# Altium schematic sheet Connections.SchDoc(Connections)
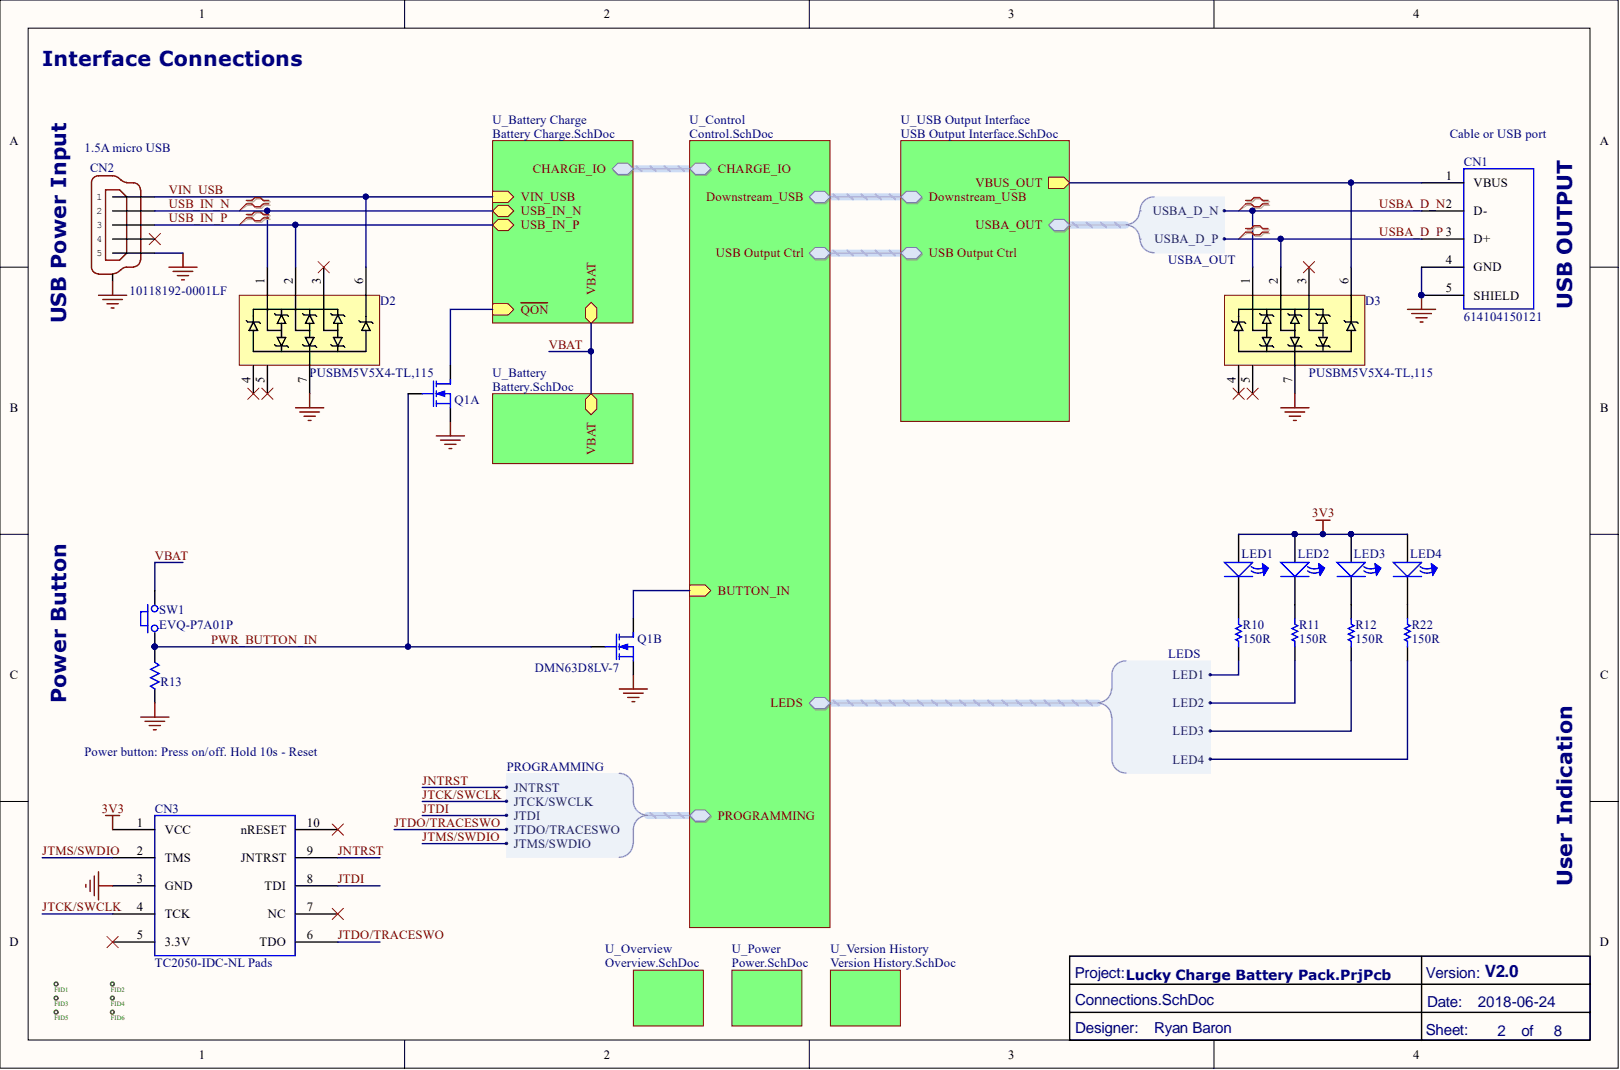
[diagram p0: Connections.SchDoc]

## Parts
CN1  614104150121  [614104150121]
CN2  10118192-0001LF  [10118192-0001LF]
CN3  TC2050-IDC-NL Pads  [TC2050-IDC-NL]  (no BOM)
D2  PUSBM5V5X4-TL,115  [SON50P160X160X50-7N120X50]
D3  PUSBM5V5X4-TL,115  [SON50P160X160X50-7N120X50]
FID1  Round Fiducial  [FIDU-ROUND]  (value not drawn)  (no BOM)
FID2  Round Fiducial  [FIDU-ROUND]  (value not drawn)  (no BOM)
FID3  Round Fiducial  [FIDU-ROUND]  (value not drawn)  (no BOM)
FID4  Round Fiducial  [FIDU-ROUND]  (value not drawn)  (no BOM)
FID5  Round Fiducial  [FIDU-ROUND]  (value not drawn)  (no BOM)
FID6  Round Fiducial  [FIDU-ROUND]  (value not drawn)  (no BOM)
LED1  VLMB1500-GS08  [LED0402]  (value not drawn)
LED2  VLMB1500-GS08  [LED0402]  (value not drawn)
LED3  VLMB1500-GS08  [LED0402]  (value not drawn)
LED4  VLMB1500-GS08  [LED0402]  (value not drawn)
Q1  DMN63D8LV-7  [SOT-563ALT]  (parts A,B)
R10  Resistance: 150R  [RES0402R]  (hidden comment: CRCW0402150RFKED)
R11  Resistance: 150R  [RES0402R]  (hidden comment: CRCW0402150RFKED)
R12  Resistance: 150R  [RES0402R]  (hidden comment: CRCW0402150RFKED)
R13  10K  [RES0402R]  (value not drawn)
R22  Resistance: 150R  [RES0402R]  (hidden comment: CRCW0402150RFKED)
SW1  EVQ-P7A01P  [EVQ-P7A01P]

## Nets
3V3: CN3.1(VCC) LED1.A LED2.A LED3.A LED4.A
GND: CN1.4(GND) CN1.5(SHIELD) CN2.0 CN2.5 CN3.3(GND) D2.7 D3.7 Q1.1 Q1.4 R13.1
JNTRST: CN3.9(JNTRST)
JTCK/SWCLK: CN3.4(TCK)
JTDI: CN3.8(TDI)
JTDO/TRACESWO: CN3.6(TDO)
JTMS/SWDIO: CN3.2(TMS)
PWR_BUTTON_IN: Q1.2 Q1.5 R13.2 SW1.1
USB_IN_N: CN2.2 D2.1
USB_IN_P: CN2.3 D2.2
USBA_D_N: CN1.2(D-) D3.1
USBA_D_P: CN1.3(D+) D3.2
VBAT: SW1.2
VIN_USB: CN2.1 D2.6
NetCN1_1: CN1.1(VBUS) D3.6
NetCN2_4: CN2.4
NetCN3_5: CN3.5(3.3V)
NetCN3_7: CN3.7(NC)
NetCN3_10: CN3.10(nRESET)
NetD2_3: D2.3
NetD2_4: D2.4
NetD2_5: D2.5
NetD3_3: D3.3
NetD3_4: D3.4
NetD3_5: D3.5
NetLED1_K: LED1.K R10.1
NetLED2_K: LED2.K R11.1
NetLED3_K: LED3.K R12.1
NetLED4_K: LED4.K R22.1
NetQ1_3: Q1.3
NetQ1_6: Q1.6
NetR10_2: R10.2
NetR11_2: R11.2
NetR12_2: R12.2
NetR22_2: R22.2
N00034:
N00035:
N00036:
N00037:
N00038:
N00039:
N00040:
N00041:
N00042:
N00043:
N00044:
N00045:
N00046:
N00047:

## Library data of the parts
CN1 = 614104150121: CONN RCPT USB TYPE A REV; Manufacturer: Wurth Electronics Inc.; Connector Type: USB - A; Current Rating: 1.5A; Gender: Receptacle; Material Flammability Rating: UL94 V-0; Mating Cycles: 1500; Number of Contacts: 4; Shielding: Shielded; Specifications: USB 2.0; Voltage - Rated: 30VAC; Category: Connectors, Interconnects; Component Kind: Standard; ComponentLink1Description: http://katalog.we-online.de/em/datasheet/614104150121.pdf; ComponentLink1URL: http://katalog.we-online.de/em/datasheet/614104150121.pdf; Contact Finish: Gold; Features: Board Lock; Ingress Protection: -; Insulation Color: Black; Library Name: PF Connectors.SchLib; Manufacturer Part Number: 614104150121; Mounting Feature: Horizontal; Mounting Type: Through Hole, Right Angle; Operating Temperature: -40°C ~ 85°C; Packaging: Cut Tape (CT); Part Status: Active; Pricing 1: 1=2.91, 10=2.673, 50=2.4746, 100=2.2763 (CAD); RoHS: RoHS Compliant; Shell/Shielding Material: Brass; Stock 1: 389; Supplier 1: Digi-Key; Termination: Solder
CN2 = 10118192-0001LF: CONN USB MICRO B RECPT SMT R/A; Manufacturer: Amphenol FCI; Connector Type: USB - micro B; Current Rating: 1.8A per Contact; Gender: Receptacle; Material Flammability Rating: UL94 V-0; Mating Cycles: 10000; Number of Contacts: 5; Shielding: Shielded; Specifications: USB 2.0; Voltage - Rated: 100VAC; Category: Connectors, Interconnects; Component Kind: Standard; ComponentLink1Description: https://cdn.amphenol-icc.com/media/wysiwyg/files/drawing/10118192.pdf; ComponentLink1URL: https://cdn.amphenol-icc.com/media/wysiwyg/files/drawing/10118192.pdf; Contact Finish: Gold; Features: Solder Retention; Ingress Protection: -; Insulation Color: Black; Library Name: Connectors.SchLib; Manufacturer Part Number: 10118192-0001LF; Mounting Feature: Flange, Horizontal; Mounting Type: Surface Mount, Right Angle; Operating Temperature: -30°C ~ 80°C; Packaging: Cut Tape (CT); Part Status: Active; Pricing 1: 1=0.58, 10=0.547, 25=0.4924, 50=0.4378, 100=0.4195, 250=0.38304, 500=0.3648 (CAD); RoHS: RoHS Compliant; Shell/Shielding Material: Steel, Stainless; Stock 1: 203700; Supplier 1: Digi-Key; Supplier Part Number 1: 609-4613-1-ND; Termination: Solder
CN3 = TC2050-IDC-NL-JTAG: CBL PLUG-OF-NAILS 10-PIN Pads; Manufacturer: Tag-Connect LLC; ComponentLink1Description: http://www.tag-connect.com/Materials/TC2050-IDC-NL%20Datasheet.pdf; ComponentLink1URL: http://www.tag-connect.com/Materials/TC2050-IDC-NL%20Datasheet.pdf; Library Name: PF Connectors.SchLib; Manufacturer Part Number: TC2050-IDC-NL
D2 D3 = PUSBM5V5X4-TL,115: TVS DIODE 5.5V 12V 6DFN1616; Manufacturer: Nexperia USA Inc.; Current - Peak Pulse (10/1000µs): 3A (8/20µs); Power - Peak Pulse: 35W; Power Line Protection: Yes; Type: Steering (Rail to Rail); Voltage - Breakdown (Min): 6.4V; Voltage - Reverse Standoff (Typ): 5.5V (Max); Applications: USB OTG; Capacitance @ Frequency: -; Category: Circuit Protection; Component Kind: Standard; ComponentLink1Description: https://assets.nexperia.com/documents/data-sheet/PUSBMXX4-TL_SER.pdf; ComponentLink1URL: https://assets.nexperia.com/documents/data-sheet/PUSBMXX4-TL_SER.pdf; Library Name: PF Passives.SchLib; Manufacturer Part Number: PUSBM5V5X4-TL,115; Mounting Type: Surface Mount; Operating Temperature: -30°C ~ 85°C (TA); Package / Case: 6-XFDFN Exposed Pad; Packaging: Cut Tape (CT); Part Status: Active; Pricing: 1=0.63, 10=0.536, 100=0.4005, 500=0.3147, 1000=0.24317 (CAD); RoHS: RoHS Compliant; Stock: 132194; Supplier 1: Digi-Key; Supplier Device Package: 6-DFN1616 (1.6x1.6); Supplier Part Number 1: 1727-1453-1-ND; Voltage - Clamping (Max) @ Ipp: 12V
FID1-FID6 = Fidu-Round: Round Fiducial - Used for Non-Origin; Profire PN: TP; Library Name: PF Mechanicals.SchLib
LED1-LED4 = VLMB1500-GS08: LED BLUE 0402 SMD; Manufacturer: Vishay Semiconductor Opto Division; Current - Test: 5mA; Lens Style/Size: Rectangle with Flat Top, 1.00mm x 0.50mm; Millicandela Rating: 28.1mcd; Viewing Angle: 130°; Voltage - Forward (Vf) (Typ): 2.9V; Wavelength - Dominant: 473nm; Wavelength - Peak: 468nm; Base Part Number: VLM1500; Category: Optoelectronics; Color: Blue; Component Kind: Standard; ComponentLink1Description: http://www.vishay.com/docs/82554/vlmo1500.pdf; ComponentLink1URL: http://www.vishay.com/docs/82554/vlmo1500.pdf; Features: -; Height (Max): 0.55mm; Lens Color: -; Lens Transparency: -; Library Name: PF Passives.SchLib; Manufacturer Part Number: VLMB1500-GS08; Mounting Type: Surface Mount; Package / Case: 0402 (1005 Metric); Packaging: Cut Tape (CT); Part Status: Active; Pricing 1: 1=0.57, 10=0.407, 25=0.3524, 100=0.2713, 250=0.22048, 500=0.16284, 1000=0.13569 (CAD); RoHS: RoHS Compliant; Size / Dimension: 1.00mm L x 0.50mm W; Stock 1: 227178; Supplier 1: Digi-Key; Supplier Device Package: 0402; Supplier Part Number 1: VLMB1500-GS08CT-ND
Q1 = DMN63D8LV-7: MOSFET 2N-CH 30V 0.26A SOT563; Manufacturer: Diodes Incorporated; Current - Continuous Drain (Id) @ 25°C: 260mA; FET Feature: Logic Level Gate; FET Type: 2 N-Channel (Dual); Gate Charge (Qg) (Max) @ Vgs: 0.87nC @ 10V; Input Capacitance (Ciss) (Max) @ Vds: 22pF @ 25V; Power - Max: 450mW; Rds On (Max) @ Id, Vgs: 2.8 Ohm @ 250mA, 10V; Vgs(th) (Max) @ Id: 1.5V @ 250µA; Base Part Number: DMN63D8L; Category: Discrete Semiconductor Products; Component Kind: Standard; ComponentLink1Description: https://www.diodes.com/assets/Datasheets/DMN63D8LV.pdf; ComponentLink1URL: https://www.diodes.com/assets/Datasheets/DMN63D8LV.pdf; Drain to Source Voltage (Vdss): 30V; Library Name: PF Transistors.SchLib; Manufacturer Part Number: DMN63D8LV-7; Mounting Type: Surface Mount; Operating Temperature: -55°C ~ 150°C (TJ); Package / Case: SOT-563, SOT-666; Packaging: Cut Tape (CT); Part Status: Active; Pricing: 1=0.57, 10=0.515, 100=0.2886, 500=0.1546, 1000=0.10513 (CAD); RoHS: RoHS Compliant; Stock: 66062; Supplier 1: Digi-Key; Supplier Device Package: SOT-563; Supplier Part Number 1: DMN63D8LV-7DICT-ND
R10-R12 R22 = CRCW0402150RFKED: RES SMD 150 OHM 1% 1/16W 0402; Manufacturer: Vishay Dale; Power (Watts): 0.063W, 1/16W; Temperature Coefficient: ±100ppm/°C; Unit Price (CAD): 0.15; Component Kind: Standard; Composition: Thick Film; Features: Automotive AEC-Q200; Manufacturer Part Number: CRCW0402150RFKED; Operating Temperature: -55°C ~ 155°C; Package / Case: 0402 (1005 Metric); Series: CRCW; Tolerance: ±1%; Library Name: Automotive Resistor 0402.SchLib
R13 = RC1005F103CS: RES SMD 10K OHM 1% 1/16W 0402; Manufacturer: Samsung Electro-Mechanics; Power (Watts): 0.063W, 1/16W; Temperature Coefficient: ±100ppm/°C; Category: Resistors; Component Kind: Standard; ComponentLink1Description: http://www.samsungsem.com/kr/support/product-search/chip-resistor/RC1005F103CS.jsp; ComponentLink1URL: http://www.samsungsem.com/kr/support/product-search/chip-resistor/RC1005F103CS.jsp; Composition: Thick Film; Failure Rate: -; Features: Moisture Resistant; Height - Seated (Max): 0.016" (0.40mm); Library Name: PF Resistors.SchLib; Manufacturer Part Number: RC1005F103CS; Operating Temperature: -55°C ~ 155°C; Package / Case: 0402 (1005 Metric); Packaging: Cut Tape (CT); Part Status: Active; Pricing 1: 1=0.15, 10=0.031, 100=0.0127, 1000=0.00569, 2500=0.00493, 5000=0.00407 (CAD); RoHS: RoHS Compliant; Size / Dimension: 0.039" L x 0.020" W (1.00mm x 0.50mm); Stock 1: 23246242; Supplier 1: Digi-Key; Supplier Device Package: 0402; Tolerance: ±1%
SW1 = EVQ-P7A01P: SWITCH TACTILE SPST-NO 0.05A 12V; Manufacturer: Panasonic Electronic Components; Actuator Orientation: Side Actuated; Actuator Type: Rectangular Button; Contact Rating @ Voltage: 0.05A @ 12VDC; Illumination: Non-Illuminated; Mechanical Life: 100,000 Cycles; Operating Force: 220gf; Outline: 3.50mm x 2.90mm; Switch Function: Off-Mom; Switch Travel: 0.20mm; Actuator Height off PCB, Vertical: -; Actuator Length, Right Angle: -; Actuator Material: -; Category: Switches; Circuit: SPST-NO; Component Kind: Standard; ComponentLink1Description: https://www3.panasonic.biz/ac/e_download/control/switch/light-touch/catalog/sw_lt_eng_3529s_side.pdf; ComponentLink1URL: https://www3.panasonic.biz/ac/e_download/control/switch/light-touch/catalog/sw_lt_eng_3529s_side.pdf; Features: -; Illumination Type, Color: -; Illumination Voltage (Nominal): -; Ingress Protection: -; Manufacturer Part Number: EVQ-P7A01P; Mounting Type: Surface Mount, Right Angle; Operating Temperature: -20°C ~ 70°C; Packaging: Cut Tape (CT); Part Status: Active; Pricing 1: 1=0.44, 10=0.423, 25=0.4076, 50=0.3922, 100=0.3772, 250=0.34696, 500=0.3017, 1000=0.25645 (CAD); RoHS: RoHS Compliant; Stock 1: 4534; Supplier 1: Digi-Key; Supplier Part Number 1: P16763CT-ND; Termination Style: Gull Wing; Library Name: PF Mechanicals.SchLib
